# Altium schematic sheet Sheet4.SchDoc(Sheet4)
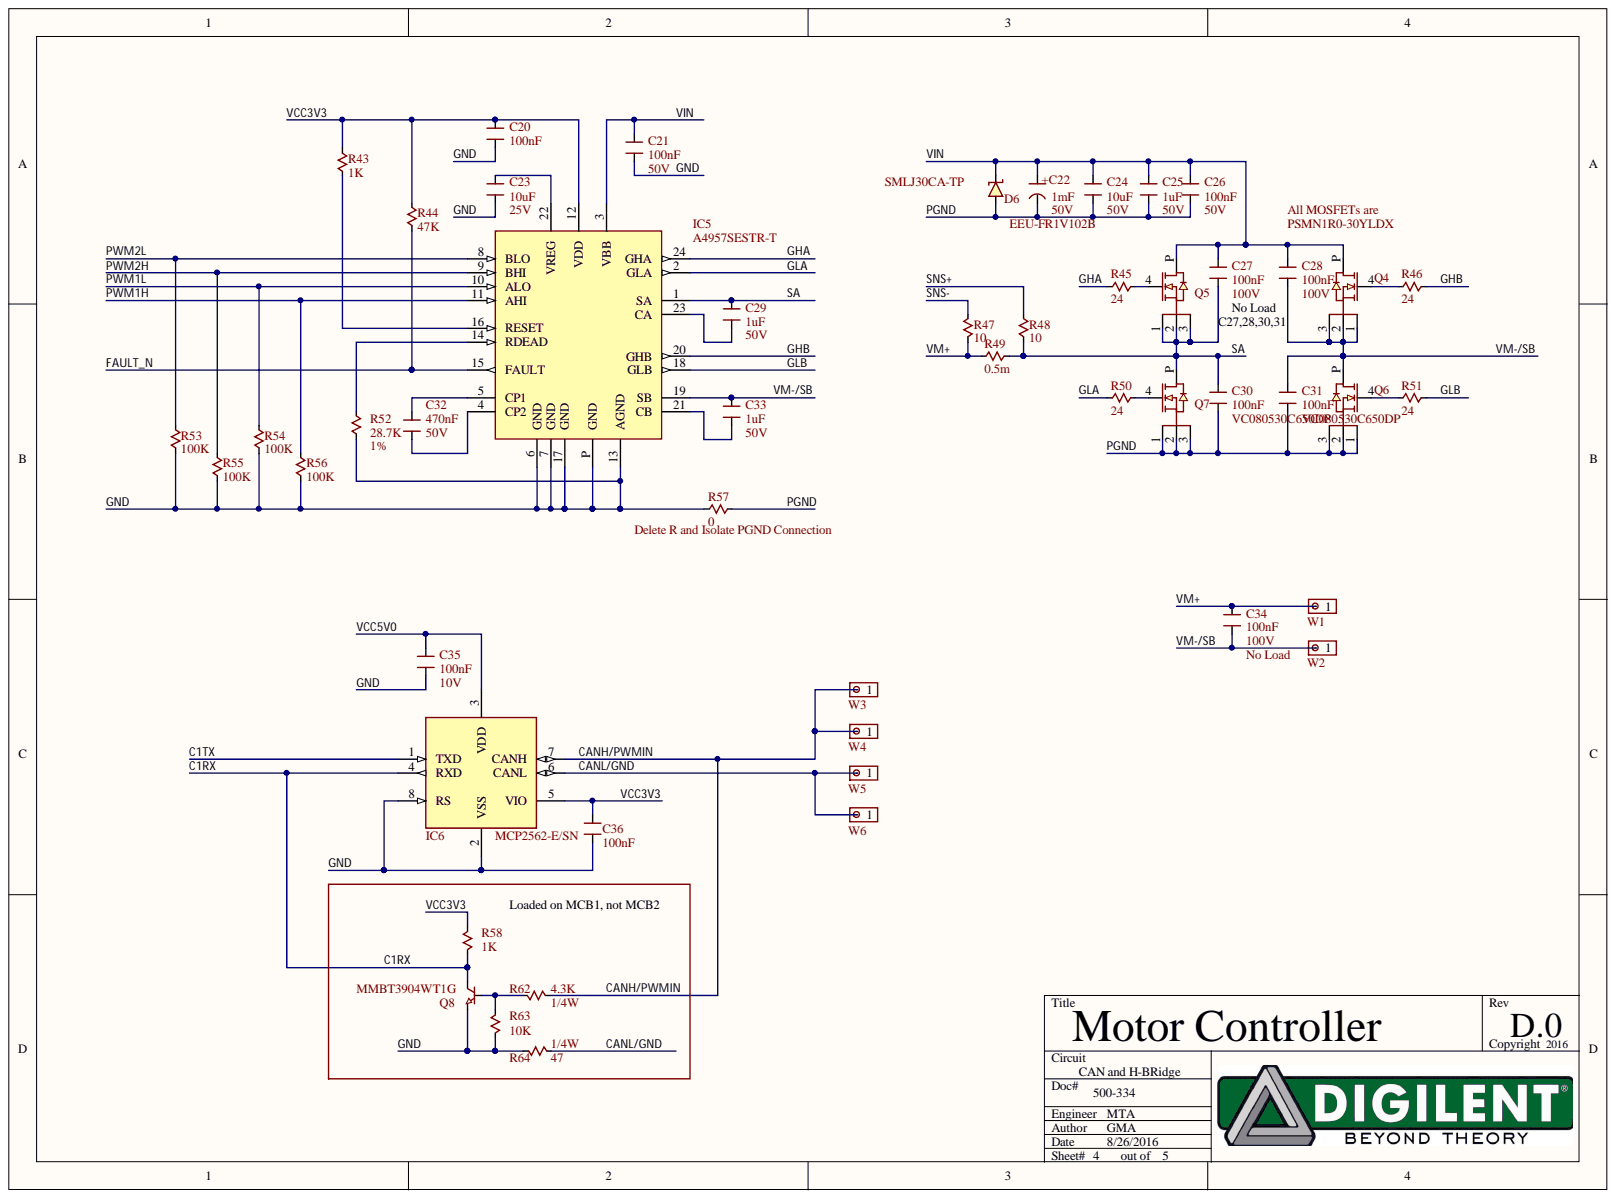
[diagram p0: Sheet4.SchDoc]

## Parts
C20  value 100nF  [CAP0402]  (hidden comment: CAPACITOR)
C21  50V  value 100nF  [CAP0402]
C22  EEU-FR1V102B  value 1mF  [CPOL-FR1V]
C23  25V  value 10uF  [CAP0805]
C24  50V  value 10uF  [CAP1206]
C25  50V  value 1uF  [CAP0805]
C26  50V  value 100nF  [CAP0402]
C27  100V  value 100nF  [CAP0603]
C28  100V  value 100nF  [CAP0603]
C29  50V  value 1uF  [CAP0603]
C30  VC080530C650DP  value 100nF  [CAP0805]
C31  VC080530C650DP  value 100nF  [CAP0805]
C32  50V  value 470nF  [CAP0402]
C33  50V  value 1uF  [CAP0603]
C34  100V  value 100nF  [CAP0603]
C35  10V  value 100nF  [CAP0402]
C36  value 100nF  [CAP0402]  (hidden comment: CAPACITOR)
D6  SMLJ30CA-TP  [SMC]
IC5  A4957SESTR-T  [QFN24]
IC6  MCP2562-E/SN  [SOIC8-MICROCHIP]
Q4  PSMN1R0-30YLDX  [LFPAK56]
Q5  PSMN1R0-30YLDX  [LFPAK56]
Q6  PSMN1R0-30YLDX  [LFPAK56]
Q7  PSMN1R0-30YLDX  [LFPAK56]
Q8  MMBT3904WT1G  [SC-70]
R43  value 1K  [RES0402]  (hidden comment: RESISTOR)
R44  value 47K  [RES0402]  (hidden comment: RESISTOR)
R45  value 24  [RES0603]  (hidden comment: 1%)
R46  value 24  [RES0603]  (hidden comment: 1%)
R47  value 10  [RES0402]  (hidden comment: CRCW040210R0FKEDHP)
R48  value 10  [RES0402]  (hidden comment: CRCW040210R0FKEDHP)
R49  value 0.5m  [RES2512]  (hidden comment: CSNL2512FTL500)
R50  value 24  [RES0603]  (hidden comment: 1%)
R51  value 24  [RES0603]  (hidden comment: 1%)
R52  1%  value 28.7K  [RES0402]
R53  value 100K  [RES0402]  (hidden comment: RESISTOR)
R54  value 100K  [RES0402]  (hidden comment: RESISTOR)
R55  value 100K  [RES0402]  (hidden comment: RESISTOR)
R56  value 100K  [RES0402]  (hidden comment: RESISTOR)
R57  value 0  [RES0805]  (hidden comment: RESISTOR)
R58  value 1K  [RES0402]  (hidden comment: RESISTOR)
R62  1/4W  value 4.3K  [RES0805]
R63  value 10K  [RES0402]  (hidden comment: RESISTOR)
R64  1/4W  value 47  [RES0805]
W1  12G Wire White  [WLAND-SMD]  (value not drawn)
W2  LoadStatus: Load  [WLAND-SMD]  (hidden comment: 12G Wire Green)
W3  20G Wire Yellow  [WLAND-SMD]  (value not drawn)
W4  20G Wire Yellow  [WLAND-SMD]  (value not drawn)
W5  20G Wire Green  [WLAND-SMD]  (value not drawn)
W6  20G Wire Green  [WLAND-SMD]  (value not drawn)

## Nets
C1RX: IC6.4(RXD) Q8.3 R58.1
C1TX: IC6.1(TXD)
CANH/PWMIN: IC6.7(CANH) R62.2 W3.1 W4.1
CANL/GND: IC6.6(CANL) R64.1 W5.1 W6.1
FAULT_N: IC5.15(FAULT) R44.2
GHA: IC5.24(GHA) R45.2
GHB: IC5.20(GHB) R46.2
GLA: IC5.2(GLA) R50.2
GLB: IC5.18(GLB) R51.2
GND: C20.2 C21.2 C23.2 C35.2 C36.2 IC5.6(GND) IC5.7(GND) IC5.13(AGND) IC5.17(GND) IC5.P(GND) IC6.2(VSS) IC6.8(RS) Q8.2 R52.2 R53.2 R54.2 R55.2 R56.2 R57.2 R63.1 R64.2
PGND: C22.2 C24.2 C25.2 C26.2 C30.2 C31.2 D6.A Q6.1 Q6.2 Q6.3 Q7.1 Q7.2 Q7.3 R57.1
PWM1H: IC5.11(AHI) R56.1
PWM1L: IC5.10(ALO) R54.1
PWM2H: IC5.9(BHI) R55.1
PWM2L: IC5.8(BLO) R53.1
SA: C27.2 C29.1 C30.1 IC5.1(SA) Q5.1 Q5.2 Q5.3 Q7.P R48.2 R49.2
SNS+: R48.1
SNS-: R47.1
VCC3V3: C20.1 C36.1 IC5.12(VDD) IC6.5(VIO) R43.2 R44.1 R58.2
VCC5V0: C35.1 IC6.3(VDD)
VIN: C21.1 C22.1 C24.1 C25.1 C26.1 C27.1 C28.1 D6.K IC5.3(VBB) Q4.P Q5.P
VM+: C34.1 R47.2 R49.1 W1.1
VM-/SB: C28.2 C31.1 C33.1 C34.2 IC5.19(SB) Q4.1 Q4.2 Q4.3 Q6.P W2.1
NetC23_1: C23.1 IC5.22(VREG)
NetC29_2: C29.2 IC5.23(CA)
NetC32_1: C32.1 IC5.5(CP1)
NetC32_2: C32.2 IC5.4(CP2)
NetC33_2: C33.2 IC5.21(CB)
NetIC5_14: IC5.14(RDEAD) R52.1
NetIC5_16: IC5.16(RESET) R43.1
NetQ4_4: Q4.4 R46.1
NetQ5_4: Q5.4 R45.1
NetQ6_4: Q6.4 R51.1
NetQ7_4: Q7.4 R50.1
NetQ8_1: Q8.1 R62.1 R63.2

## Library data of the parts
C20 C21 C23-C26 C29-C33 C35 C36 = CAPACITOR: LoadStatus: Load; Component Kind: Standard; Description: Capacitor; Library Name: DigilentUN.IntLib
C22 = CPOL: Polarized Capacitor; LoadStatus: Load; Component Kind: Standard; Library Name: DigilentUN.IntLib
C27 C28 C34 = CAPACITOR: LoadStatus: No Load; Component Kind: Standard; Description: Capacitor; Library Name: DigilentUN.IntLib
D6 = DIODE-SCH: Schotkey Diode NOT GENERIC; Manufacturer: Littlefuse; LoadStatus: No Load; Component Kind: Standard; Library Name: DigilentUN.IntLib
IC5 = A4957SESTR-T: Full Bridge MOSFET Driver; Manufacturer: Allegro; LoadStatus: Load; Component Kind: Standard; Library Name: DigilentUN.IntLib
IC6 = MCP2562: High-Speed CAN Transceiver; Manufacturer: Microchip; LoadStatus: Load; Component Kind: Standard; Library Name: DigilentUN.IntLib
Q4-Q7 = PSMN1R0: N-Channel NextPower-S3 MOSFET 40V, 14.9A, 1.1mOhm; Manufacturer: NXP; LoadStatus: Load; Component Kind: Standard; Library Name: DigilentUN.IntLib
Q8 = MBT3904WT1: General purpose Transistor, 40V, PNP; Manufacturer: ON Semiconductor; LoadStatus: No Load; Component Kind: Standard; Library Name: DigilentUN.IntLib
R43-R57 = RESISTOR: LoadStatus: Load; Component Kind: Standard; Description: Resistor; Library Name: DigilentUN.IntLib
R58 R62-R64 = RESISTOR: LoadStatus: No Load; Component Kind: Standard; Description: Resistor; Library Name: DigilentUN.IntLib
W1 W3-W6 = WLAND-1X1: 42hil Hole for Wire soldering; Manufacturer: NA; LoadStatus: Load; Component Kind: Standard; Library Name: DigilentUN.IntLib
W2 = WLAND-1X1: 42hil Hole for Wire soldering; Manufacturer: NA; Component Kind: Standard; Library Name: DigilentUN.IntLib
